# Altium schematic sheet latch_sdc_sch.SchDoc(latch_sdc_sch)
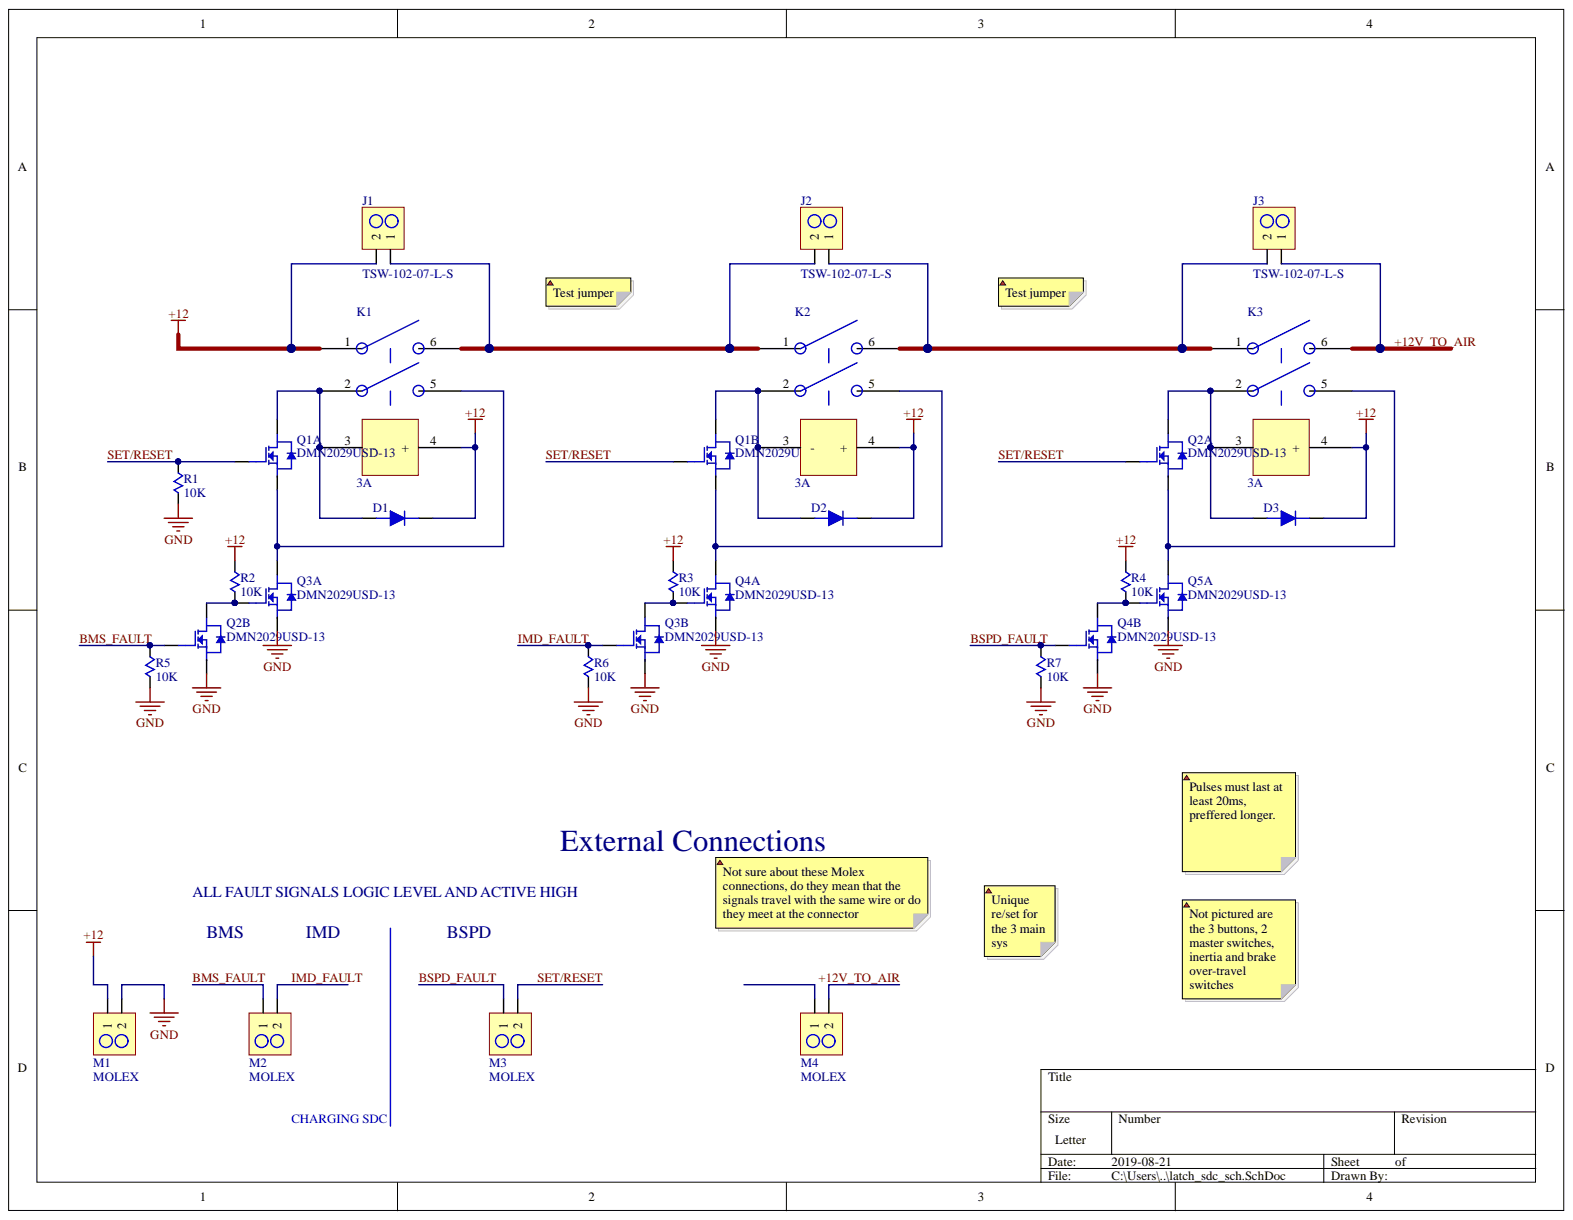
[diagram p0: latch_sdc_sch.SchDoc]

## Parts
D1  SD560BTR  [DIOM4436X26N]  (value not drawn)
D2  SD560BTR  [DIOM4436X26N]  (value not drawn)
D3  SD560BTR  [DIOM4436X26N]  (value not drawn)
J1  TSW-102-07-L-S  [TSW-102-XX-X-S]
J2  TSW-102-07-L-S  [TSW-102-XX-X-S]
J3  TSW-102-07-L-S  [TSW-102-XX-X-S]
K1  3A  [RELAY02_FOOTPRINT]
K2  3A  [RELAY02_FOOTPRINT]
K3  3A  [RELAY02_FOOTPRINT]
M1  MOLEX  [MOLEX 1]
M2  MOLEX  [MOLEX 1]
M3  MOLEX  [MOLEX 1]
M4  MOLEX  [MOLEX 1]
Q1  DMN2029USD-13  [SOIC127P600X175-8N]  (parts A,B)
Q2  DMN2029USD-13  [SOIC127P600X175-8N]  (parts A,B)
Q3  DMN2029USD-13  [SOIC127P600X175-8N]  (parts A,B)
Q4  DMN2029USD-13  [SOIC127P600X175-8N]  (parts A,B)
Q5  DMN2029USD-13  [SOIC127P600X175-8N]  (part A)
R1  10K  [10KOHM]
R2  10K  [10KOHM]
R3  10K  [10KOHM]
R4  10K  [10KOHM]
R5  10K  [10KOHM]
R6  10K  [10KOHM]
R7  10K  [10KOHM]

## Nets
+12: D1.2 D2.2 D3.2 J1.2 K1.1 K1.4(+) K2.4(+) K3.4(+) M1.1 R2.1 R3.1 R4.1
GND: M1.2 Q2.3 Q3.1 Q3.3 Q4.1 Q4.3 Q5.1 R1.2 R5.2 R6.2 R7.2
+12V_TO_AIR: J3.1 K3.6 M4.2
BMS_FAULT: M2.1 Q2.4 R5.1
BSPD_FAULT: M3.1 Q4.4 R7.1
IMD_FAULT: M2.2 Q3.4 R6.1
SET/RESET: M3.2 Q1.2 Q1.4 Q2.2 R1.1
NetD1_1: D1.1 K1.2 K1.3(-) Q1.8
NetD2_1: D2.1 K2.2 K2.3(-) Q1.5
NetD3_1: D3.1 K3.2 K3.3(-) Q2.8
NetJ1_1: J1.1 J2.2 K1.6 K2.1
NetJ2_1: J2.1 J3.2 K2.6 K3.1
NetK1_5: K1.5 Q1.1 Q3.8
NetK2_5: K2.5 Q1.3 Q4.8
NetK3_5: K3.5 Q2.1 Q5.8
NetQ2_5: Q2.5 Q3.2 R2.2
NetQ3_5: Q3.5 Q4.2 R3.2
NetQ4_5: Q4.5 Q5.2 R4.2

Not connected: M4 1

## Library data of the parts
D1-D3 = SD560BTR: DIODE GEN PURP 600V 5A SMB; Manufacturer: SMC; Supplier Part Number 1: 1655-1530-1-ND; Supplier 1: Digi-Key; Stock 1: 109105; Pricing 1: 1=0.5, 10=0.358, 100=0.2106, 500=0.11922, 1000=0.0914 (USD); Packaging: Tape and Reel; Mount: Surface Mount; Max Reverse Voltage (DC): 600V; Manufacturer Part Number: SD560BTR; Component Kind: Standard; Case/Package: SMB; Average Rectified Current: 5A; Library Name: latch_sdc_schlib.SchLib
J1-J3 = TSW-102-07-L-S: CONN HEADER VERT 2POS 2.54MM; Manufacturer: Samtec; Voltage Rating: 550V; Number of Positions: 2; Max Current Rating: 7.6A; Gender: Male; Connector Type: Male Pin; Width: 2.54mm; Termination: Solder; Supplier Part Number 1: SAM1031-02-ND; Supplier 1: Digi-Key; Stock 1: 176; REACH SVHC: No SVHC; Radiation Hardening: No; Pricing 1: 1=0.22, 10=0.211, 25=0.1796, 50=0.1572, 100=0.146, 250=0.12916, 500=0.12356 (USD); Pitch: 2.54mm; Packaging: Bulk; Package Quantity: 1; Orientation: Straight; Number of Rows: 1; Number of Contacts: 2; Mount: Through Hole; Min Operating Temperature: -55°C; Max Voltage Rating (AC): 550V; Max Operating Temperature: 125°C; Manufacturer Part Number: TSW-102-07-L-S; Length: 5.08mm; Lead Free: Lead Free; Housing Material: Polyester; Housing Color: Black; Depth: 2.54mm; Current Rating: 7.6A; Contact Plating: Gold; Contact Mating Length: 0.23inch; Contact Material: Bronze; ComponentLink4URL: http://www.farnell.com/datasheets/1596380.pdf?src-supplier=Element14; ComponentLink4Description: http://www.farnell.com/datasheets/1596380.pdf?src-supplier=Element14; ComponentLink3URL: http://suddendocs.samtec.com/prints/tsw-xxx-xx-x-x-xx-xxx-footprint.pdf?src-supplier=Digi-Key; ComponentLink3Description: http://suddendocs.samtec.com/prints/tsw-xxx-xx-x-x-xx-xxx-footprint.pdf?src-supplier=Digi-Key; ComponentLink2URL: http://suddendocs.samtec.com/prints/tsw-xxx-xx-x-x-xx-xxx-footprint.pdf?src-supplier=Avnet+Europe; ComponentLink2Description: http://suddendocs.samtec.com/prints/tsw-xxx-xx-x-x-xx-xxx-footprint.pdf?src-supplier=Avnet+Europe; ComponentLink1URL: http://suddendocs.samtec.com/prints/tsw-xxx-xx-x-x-xx-xxx-footprint.pdf?src-supplier=Avnet; ComponentLink1Description: http://suddendocs.samtec.com/prints/tsw-xxx-xx-x-x-xx-xxx-footprint.pdf?src-supplier=Avnet; Component Kind: Standard; Color: Black; Library Name: latch_sdc_schlib.SchLib
K1-K3 = OSA-SS-212DM3,000: RELAY TE CONNECTIVITY / OEG         OSA-SS-212DM3,000             General Purpose Relay, OSA Series, Power, Non Latching, DPST-NO, 12 VDC, 3 A; Throw Configuration: DPST; Release Time: 10ms; Operate Time: 20ms; Contact Voltage Rating (AC): 240V; Coil Voltage (DC): 12V; Coil Type: Non-Latching; Coil Resistance: 270R; Coil Power: 600mW; Coil Current: 45mA; Width: 12.9mm; Weight: 13g; Termination: PCB; Supplier Part Number 1: OSA-SS-212DM3,000-ND; Supplier 1: Digi-Key; Stock 1: 2623; Relay Type: General Purpose; REACH SVHC: Unknown; Pricing 1: 1=2.54, 10=2.385, 25=2.1204, 50=2.0142, 100=1.9083, 250=1.69624, 500=1.59022, 1000=1.48421, 5000=1.4312 (USD); Packaging: Box; Package Quantity: 1000; Mount: Through Hole; Min Operating Temperature: -30°C; Max Operating Temperature: 60°C; Manufacturer Part Number: OSA-SS-212DM3,000; Manufacturer: TE Connectivity; Length: 24.4mm; Height: 0.976inch; ELV: Compliant; Contact Material: Silver; Contact Current Rating: 3A; ComponentLink3URL: http://www.te.com/commerce/DocumentDelivery/DDEController?Action=srchrtrv&DocNm=5-1419124-6&DocType=Customer+Drawing&DocLang=English&src-supplier=Digi-Key; ComponentLink3Description: http://www.te.com/commerce/DocumentDelivery/DDEController?Action=srchrtrv&DocNm=5-1419124-6&DocType=Customer+Drawing&DocLang=English&src-supplier=Digi-Key; ComponentLink2URL: http://www.farnell.com/cad/1652820.pdf?src-supplier=Element14; ComponentLink2Description: http://www.farnell.com/cad/1652820.pdf?src-supplier=Element14; ComponentLink1URL: http://www.farnell.com/datasheets/1652820.pdf?src-supplier=Farnell; ComponentLink1Description: http://www.farnell.com/datasheets/1652820.pdf?src-supplier=Farnell; Component Kind: Standard; Library Name: latch_sdc_schlib.SchLib
M1-M4 = 43650-0200: MOLEX - 43650-0200 - CONNECTOR, HEADER, 2POS, 1ROW, 3MM; Working Voltage: 250V; Voltage Rating: 600V; Number of Positions: 2; Insulation Resistance: 1GR; Glow Wire Compliant: Compliant; Gender: Male; Current Rating: 5A; Contact Resistance: 10mR; Connector Type: Plug,Socket; Voltage Rating (DC): 250V; Voltage Rating (AC): 250V; Termination: Solder; Supplier Part Number 1: 0436500200; Supplier 1: Avnet; Stock 1: 0; REACH SVHC: Unknown; Radiation Hardening: No; Pricing 1: 1=0.39494, 10=0.36394, 25=0.34123, 50=0.33065, 100=0.32119 (USD); Pitch: 3mm; Packaging: Tray; Package Quantity: 3927; Orientation: Right Angle; Number of Rows: 1; Number of Contacts: 2; Number of Circuits: 2; Mount: Through Hole; Min Operating Temperature: -40°C; Max Operating Temperature: 105°C; Manufacturer Part Number: 43650-0200; Manufacturer: Molex; Length: 9.65mm; Lead Free: Lead Free; Housing Material: Thermoplastic; Housing Color: Black; Height: 5.57mm; Halogen Free: Low Halogen; Flammability Rating: UL94 V-0; Depth: 9.9mm; Contact Plating: Tin; Contact Material: Brass,Tin; ComponentLink9URL: http://www.farnell.com/datasheets/1709823.pdf?src-supplier=Element14; ComponentLink9Description: http://www.farnell.com/datasheets/1709823.pdf?src-supplier=Element14; ComponentLink8URL: http://www.molex.com/webdocs/datasheets/pdf/en-us/0436500200_PCB_HEADERS.pdf?src-supplier=Avnet+Europe; ComponentLink8Description: http://www.molex.com/webdocs/datasheets/pdf/en-us/0436500200_PCB_HEADERS.pdf?src-supplier=Avnet+Europe; ComponentLink7URL: http://uk.rs-online.com/webdocs/13c3/0900766b813c3b7d.pdf?src-supplier=RSComponents; ComponentLink7Description: http://uk.rs-online.com/webdocs/13c3/0900766b813c3b7d.pdf?src-supplier=RSComponents; ComponentLink6URL: http://www.mouser.com/ds/2/276/0436500200_PCB_HEADERS-148844.pdf?src-supplier=Mouser; ComponentLink6Description: http://www.mouser.com/ds/2/276/0436500200_PCB_HEADERS-148844.pdf?src-supplier=Mouser; ComponentLink5URL: http://www.jameco.com/Jameco/Products/ProdDS/884053.pdf?src-supplier=Jameco; ComponentLink5Description: http://www.jameco.com/Jameco/Products/ProdDS/884053.pdf?src-supplier=Jameco; ComponentLink4URL: https://www.distrelec.biz/Web/Downloads/20/66/04402066.pdf?mime=application%2Fpdf&src-supplier=Distrelec; ComponentLink4Description: https://www.distrelec.biz/Web/Downloads/20/66/04402066.pdf?mime=application%2Fpdf&src-supplier=Distrelec; ComponentLink3URL: http://www.farnell.com/datasheets/1933359.pdf?src-supplier=Element14; ComponentLink3Description: http://www.farnell.com/datasheets/1933359.pdf?src-supplier=Element14; ComponentLink2URL: http://www.onlinecomponents.com/productFiles/mf-MOL/1029720436500200_pcb_headers.pdf?src-supplier=Onlinecomponents.com; ComponentLink2Description: http://www.onlinecomponents.com/productFiles/mf-MOL/1029720436500200_pcb_headers.pdf?src-supplier=Onlinecomponents.com; ComponentLink1URL: http://www.molex.com/webdocs/datasheets/pdf/en-us/0436500200_PCB_HEADERS.pdf?src-supplier=Avnet; ComponentLink1Description: http://www.molex.com/webdocs/datasheets/pdf/en-us/0436500200_PCB_HEADERS.pdf?src-supplier=Avnet; Component Kind: Standard; Color: Black; Library Name: latch_sdc_schlib.SchLib
Q1-Q5 = DMN2029USD-13: MOSFET 2N-CH 20V 5.8A 8SO; Manufacturer: Diodes; Turn-On Delay Time: 16.5ns; Turn-Off Delay Time: 119.3ns; Rise Time: 33.3ns; Rds On Max: 25mR; Max Power Dissipation: 1.2W; Input Capacitance: 1.171nF; Gate to Source Voltage (Vgs): 8V; FET Type: 2 N-Channel; Fall Time: 53.5ns; Drain to Source Resistance: 35mR; Width: 3.95mm; Supplier Part Number 1: DMN2029USD-13DICT-ND; Supplier 1: Digi-Key; Stock 1: 1364; REACH SVHC: No SVHC; Radiation Hardening: No; Pricing 1: 1=0.47, 10=0.397, 100=0.2974, 500=0.21812, 1000=0.16854 (USD); Packaging: Tape and Reel; Package Quantity: 2500; Number of Pins: 8; Mount: Surface Mount; Min Operating Temperature: -55°C; Max Operating Temperature: 150°C; Manufacturer Part Number: DMN2029USD-13; Length: 4.95mm; Height: 1.5mm; Drain to Source Voltage (Vdss): 20V; Continuous Drain Current (ID): 5.8A; ComponentLink1URL: https://www.diodes.com/assets/Datasheets/DMN2029USD.pdf?src-supplier=Digi-Key; ComponentLink1Description: https://www.diodes.com/assets/Datasheets/DMN2029USD.pdf?src-supplier=Digi-Key; Component Kind: Standard; Case/Package: SO; Library Name: latch_sdc_schlib.SchLib
R1-R7 = RNCP0603FTD10K0: RES 10K OHM 1% 1/8W 0603; Manufacturer: Stackpole Electronics; Temperature Coefficient: 100ppm/°C; Resistance: 10kR; Max Power Dissipation: 125mW; Width: 0.031inch; Tolerance: 1%; Supplier Part Number 1: RNCP0603FTD10K0DKR-ND; Supplier 1: Digi-Key; Stock 1: 85524; Radiation Hardening: No; Pricing 1: 1=0.1, 10=0.067, 100=0.0273, 1000=0.01224, 2500=0.01063 (USD); Packaging: Tape and Reel; Number of Terminations: 2; Mount: Surface Mount; Min Operating Temperature: -55°C; Max Operating Temperature: 155°C; Manufacturer Part Number: RNCP0603FTD10K0; Length: 0.059inch; Lead Free: Lead Free; Height: 0.02inch; Features: Moisture Resistant,Anti-Sulfur; Contact Plating: Tin,Matte; Composition: Thin Film; Component Kind: Standard; Case Code (Metric): 1608; Case Code (Imperial): 0603; Case/Package: 0603; Library Name: latch_sdc_schlib.SchLib
